# Altium schematic sheet MCU_Power.SchDoc
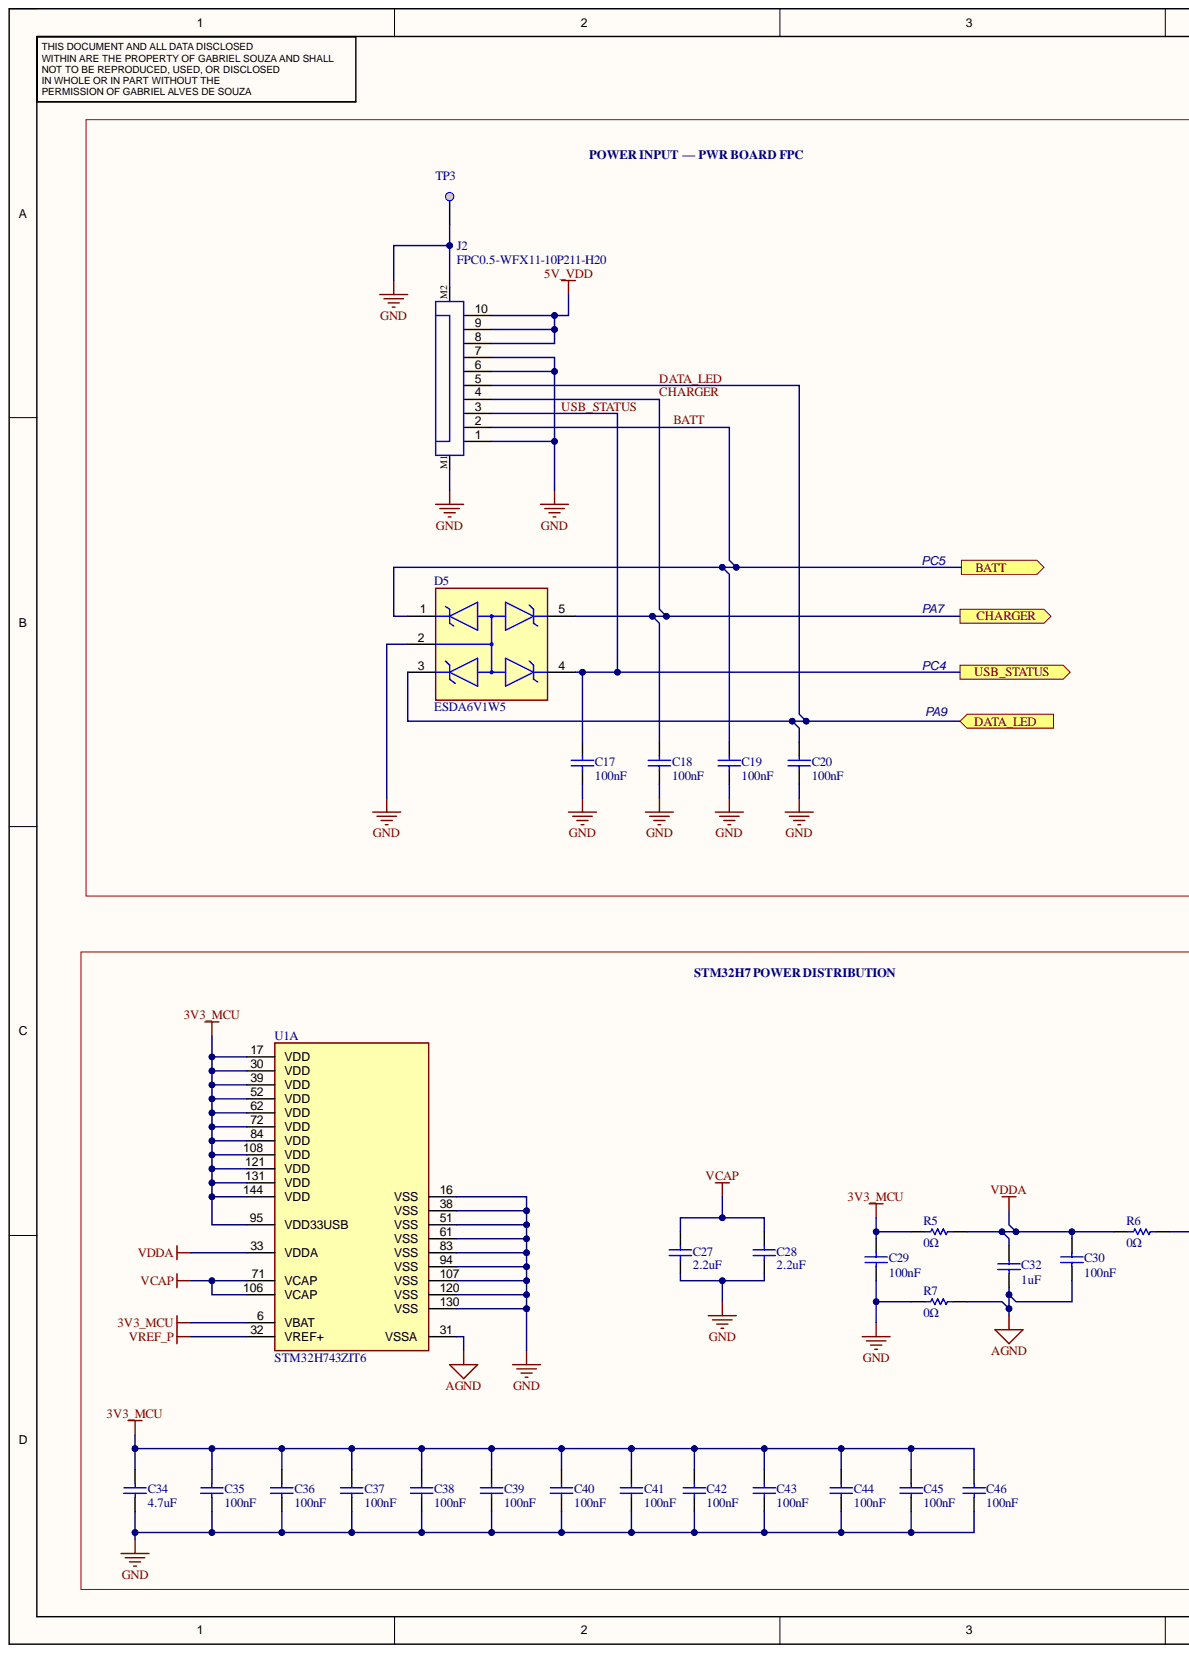
[diagram p0: MCU_Power.SchDoc, left]
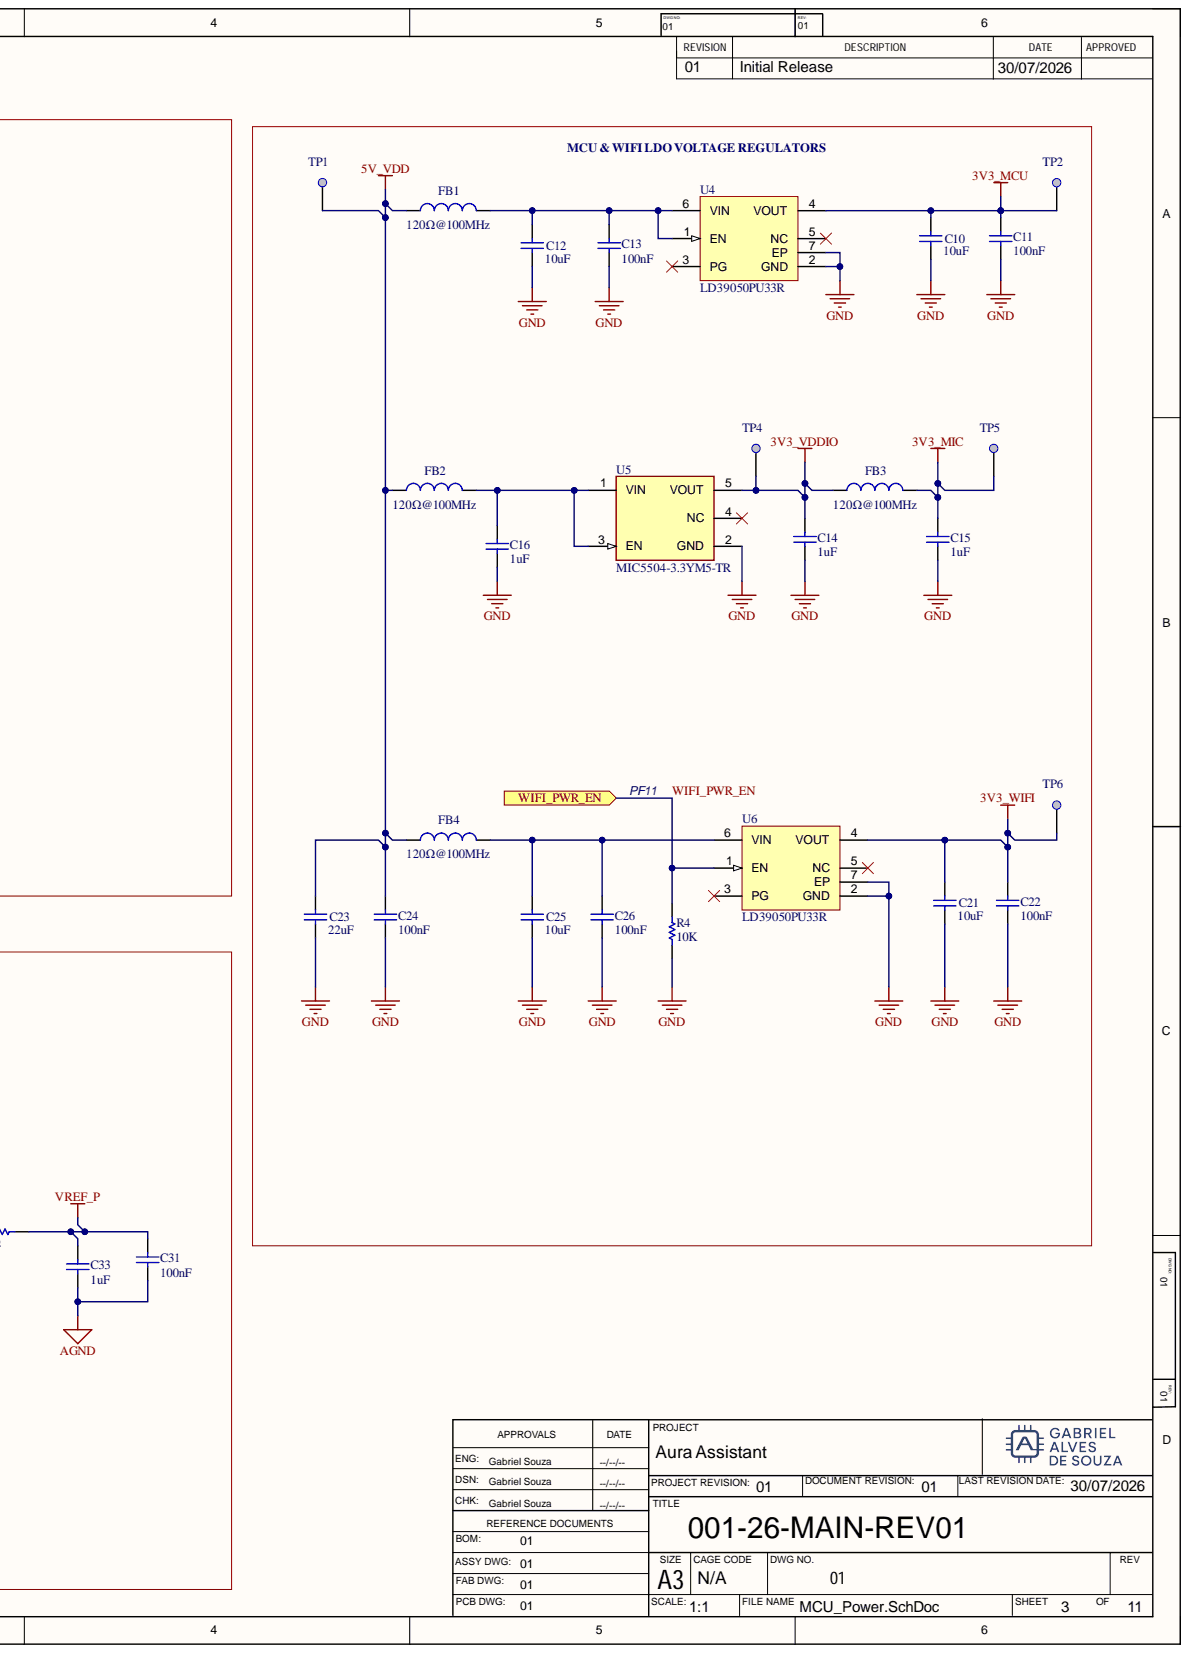
[diagram p1: MCU_Power.SchDoc, right]

## Parts
C10  value 10uF  [C_0805_IPC_N]  (hidden comment: Capacitor)
C11  value 100nF  [C_0402_IPC_N]  (hidden comment: Capacitor)
C12  value 10uF  [C_0805_IPC_N]  (hidden comment: Capacitor)
C13  value 100nF  [C_0402_IPC_N]  (hidden comment: Capacitor)
C14  value 1uF  [C_0402_IPC_N]  (hidden comment: Capacitor)
C15  value 1uF  [C_0402_IPC_N]  (hidden comment: Capacitor)
C16  value 1uF  [C_0402_IPC_N]  (hidden comment: Capacitor)
C17  value 100nF  [C_0402_IPC_N]  (hidden comment: Capacitor)
C18  value 100nF  [C_0402_IPC_N]  (hidden comment: Capacitor)
C19  value 100nF  [C_0402_IPC_N]  (hidden comment: Capacitor)
C20  value 100nF  [C_0402_IPC_N]  (hidden comment: Capacitor)
C21  value 10uF  [C_0805_IPC_N]  (hidden comment: Capacitor)
C22  value 100nF  [C_0402_IPC_N]  (hidden comment: Capacitor)
C23  value 22uF  [C_1206_IPC_N]  (hidden comment: Capacitor)
C24  value 100nF  [C_0402_IPC_N]  (hidden comment: Capacitor)
C25  value 10uF  [C_0805_IPC_N]  (hidden comment: Capacitor)
C26  value 100nF  [C_0402_IPC_N]  (hidden comment: Capacitor)
C27  value 2.2uF  [C_0402_IPC_N]  (hidden comment: Capacitor)
C28  value 2.2uF  [C_0402_IPC_N]  (hidden comment: Capacitor)
C29  value 100nF  [C_0402_IPC_N]  (hidden comment: Capacitor)
C30  value 100nF  [C_0402_IPC_N]  (hidden comment: Capacitor)
C31  value 100nF  [C_0402_IPC_N]  (hidden comment: Capacitor)
C32  value 1uF  [C_0402_IPC_N]  (hidden comment: Capacitor)
C33  value 1uF  [C_0402_IPC_N]  (hidden comment: Capacitor)
C34  value 4.7uF  [C_0805_IPC_N]  (hidden comment: Capacitor)
C35  value 100nF  [C_0402_IPC_N]  (hidden comment: Capacitor)
C36  value 100nF  [C_0402_IPC_N]  (hidden comment: Capacitor)
C37  value 100nF  [C_0402_IPC_N]  (hidden comment: Capacitor)
C38  value 100nF  [C_0402_IPC_N]  (hidden comment: Capacitor)
C39  value 100nF  [C_0402_IPC_N]  (hidden comment: Capacitor)
C40  value 100nF  [C_0402_IPC_N]  (hidden comment: Capacitor)
C41  value 100nF  [C_0402_IPC_N]  (hidden comment: Capacitor)
C42  value 100nF  [C_0402_IPC_N]  (hidden comment: Capacitor)
C43  value 100nF  [C_0402_IPC_N]  (hidden comment: Capacitor)
C44  value 100nF  [C_0402_IPC_N]  (hidden comment: Capacitor)
C45  value 100nF  [C_0402_IPC_N]  (hidden comment: Capacitor)
C46  value 100nF  [C_0402_IPC_N]  (hidden comment: Capacitor)
D5  ESDA6V1W5  [FP-SOT323-5L-MFG]
FB1  value 120Ω@100MHz  [L_0603_IPC_N]  (hidden comment: Inductor)
FB2  value 120Ω@100MHz  [L_0603_IPC_N]  (hidden comment: Inductor)
FB3  value 120Ω@100MHz  [L_0603_IPC_N]  (hidden comment: Inductor)
FB4  value 120Ω@100MHz  [L_0603_IPC_N]  (hidden comment: Inductor)
J2  FPC0.5-WFX11-10P211-H20  [687110149022]
R4  value 10K  [R_0603_IPC_N]  (hidden comment: Resistor)
R5  value 0Ω  [R_0603_IPC_N]  (hidden comment: Resistor)
R6  value 0Ω  [R_0603_IPC_N]  (hidden comment: Resistor)
R7  value 0Ω  [R_0603_IPC_N]  (hidden comment: Resistor)
TP1  Test Point  [TP_SMD_2MM]  (value not drawn)  (no BOM)
TP2  Test Point  [TP_SMD_2MM]  (value not drawn)  (no BOM)
TP3  Test Point  [TP_SMD_2MM]  (value not drawn)  (no BOM)
TP4  Test Point  [TP_SMD_2MM]  (value not drawn)  (no BOM)
TP5  Test Point  [TP_SMD_2MM]  (value not drawn)  (no BOM)
TP6  Test Point  [TP_SMD_2MM]  (value not drawn)  (no BOM)
U1  STM32H743ZIT6  [FP-LQFP144-1A-MFG]  (part A)
U4  LD39050PU33R  [DFN6D_L]
U5  MIC5504-3.3YM5-TR  [FP-SOT23-5LD-PL-1-IPC_B]
U6  LD39050PU33R  [DFN6D_L]

## Ports
BATT, CHARGER, DATA_LED, USB_STATUS, WIFI_PWR_EN

## Nets
3V3_MCU: C10.2 C11.2 C29.2 C34.2 C35.2 C36.2 C37.2 C38.2 C39.2 C40.2 C41.2 C42.2 C43.2 C44.2 C45.2 C46.2 R5.1 TP2.1 U1.6(VBAT) U1.17(VDD) U1.30(VDD) U1.39(VDD) U1.52(VDD) U1.62(VDD) U1.72(VDD) U1.84(VDD) U1.95(VDD33USB) U1.108(VDD) U1.121(VDD) U1.131(VDD) U1.144(VDD) U4.4(VOUT)
3V3_MIC: C15.2 FB3.2 TP5.1
3V3_VDDIO: C14.2 FB3.1 TP4.1 U5.5(VOUT)
3V3_WIFI: C21.2 C22.2 TP6.1 U6.4(VOUT)
5V_VDD: C23.2 C24.2 FB1.1 FB2.1 FB4.1 J2.8 J2.9 J2.10 TP1.1
AGND: C30.1 C31.1 C32.1 C33.1 R7.2 U1.31(VSSA)
GND: C10.1 C11.1 C12.1 C13.1 C14.1 C15.1 C16.1 C17.1 C18.1 C19.1 C20.1 C21.1 C22.1 C23.1 C24.1 C25.1 C26.1 C27.1 C28.1 C29.1 C34.1 C35.1 C36.1 C37.1 C38.1 C39.1 C40.1 C41.1 C42.1 C43.1 C44.1 C45.1 C46.1 D5.2 J2.1 J2.6 J2.7 J2.M1 J2.M2 R4.1 R7.1 TP3.1 U1.16(VSS) U1.38(VSS) U1.51(VSS) U1.61(VSS) U1.83(VSS) U1.94(VSS) U1.107(VSS) U1.120(VSS) U1.130(VSS) U4.2(GND) U4.7(EP) U5.2(GND) U6.2(GND) U6.7(EP)
VCAP: C27.2 C28.2 U1.71(VCAP) U1.106(VCAP)
VDDA: C30.2 C32.2 R5.2 R6.1 U1.33(VDDA)
VREF_P: C31.2 C33.2 R6.2 U1.32(VREF+)
BATT: C19.2 D5.1 J2.2 ; port BATT
CHARGER: C18.2 D5.5 J2.4 ; port CHARGER
DATA_LED: C20.2 D5.3 J2.5 ; port DATA_LED
USB_STATUS: C17.2 D5.4 J2.3 ; port USB_STATUS
WIFI_PWR_EN: R4.2 U6.1(EN) ; port WIFI_PWR_EN
NetC12_2: C12.2 C13.2 FB1.2 U4.1(EN) U4.6(VIN)
NetC16_2: C16.2 FB2.2 U5.1(VIN) U5.3(EN)
NetC25_2: C25.2 C26.2 FB4.2 U6.6(VIN)

Not connected: U4 3(PG) 5(NC); U5 4(NC); U6 3(PG) 5(NC)

## Library data of the parts
C10 C12 C21 C25 = CAP: Capacitor, MLCC, 10uF, 16V, X5R, +/-10%, 0805, T=0,5mm; Manufacturer: muRata; Manufacturer_Part_Number: GRM21BR61C106KE15K; Component Kind: Standard; ComponentLink1Description: Datasheet; ComponentLink1URL: https://www.lcsc.com/datasheet/C338032.pdf?spm=wm.sxq.inf.ggs___wm.fly.bg.6.xh&lcsc_vid=TlIKVlcFRVJWVl1WQVFfBlQDRFgPAVVQRFhaBQIFFgQxVlNeRVRbU1RQQ1dWUTtW; Order_Code_LCSC: C408141; Package: 0805; Library Name: Gabriel_Lib.IntLib
C11 C13 C17-C20 C22 C24 C26 C29-C31 C35-C46 = CAP: Capacitor, MLCC, 100nF, 16V, X7R, +/-10%, 0402, T=0,5mm; Manufacturer: TDK; Manufacturer_Part_Number: C1005X7R1C104KT000F; Component Kind: Standard; ComponentLink1Description: Datasheet; ComponentLink1URL: https://www.lcsc.com/datasheet/C338032.pdf?spm=wm.sxq.inf.ggs___wm.fly.bg.6.xh&lcsc_vid=TlIKVlcFRVJWVl1WQVFfBlQDRFgPAVVQRFhaBQIFFgQxVlNeRVRbU1RQQ1dWUTtW; Order_Code_LCSC: C338032; Package: 0402; Library Name: Gabriel_Lib.IntLib
C14-C16 C32 C33 = CAP: Capacitor, MLCC, 1uF, 10V, X5R, +/-10%, 0402, T=0,5mm; Manufacturer: FOJAN; Manufacturer_Part_Number: FCC0402X105K100AT; Component Kind: Standard; ComponentLink1Description: Datasheet; ComponentLink1URL: https://www.lcsc.com/datasheet/C5137473.pdf?spm=wm.sxq.inf.ggs___wm.fly.bg.0.xh&lcsc_vid=TlIKVlcFRVJWVl1WQVFfBlQDRFgPAVVQRFhaBQIFFgQxVlNeRVRbU1RQQ1dWUTtW; Order_Code_LCSC: C5137473; Package: 0402; Library Name: Gabriel_Lib.IntLib
C23 = CAP: Capacitor, MLCC, 22uF, 16V, X5R, +/-10%, 1206, T=0,5mm; Manufacturer: muRata; Manufacturer_Part_Number: GRM31CR61C226KE15L; Component Kind: Standard; ComponentLink1Description: Datasheet; ComponentLink1URL: https://www.lcsc.com/datasheet/C338032.pdf?spm=wm.sxq.inf.ggs___wm.fly.bg.6.xh&lcsc_vid=TlIKVlcFRVJWVl1WQVFfBlQDRFgPAVVQRFhaBQIFFgQxVlNeRVRbU1RQQ1dWUTtW; Order_Code_LCSC: C77088; Package: 1206; Library Name: Gabriel_Lib.IntLib
C27 C28 = CAP: Capacitor, MLCC, 2.2uF, 10V, X5R, +/-10%, 0402, T=0,5mm; Manufacturer: YAGEO; Manufacturer_Part_Number: CC0402KRX5R6BB225; Component Kind: Standard; ComponentLink1Description: Datasheet; ComponentLink1URL: https://www.lcsc.com/datasheet/C326606.pdf?spm=wm.sxq.inf.ggs___wm.fly.bg.0.xh&lcsc_vid=TlIKVlcFRVJWVl1WQVFfBlQDRFgPAVVQRFhaBQIFFgQxVlNeRVRbU1RQQ1dWUTtW; Order_Code_LCSC: C326606; Package: 0402; Library Name: Gabriel_Lib.IntLib
C34 = CAP: Capacitor, MLCC, 4.7uF, 10V, X5R, +/-10%, 0805, T=0,5mm; Manufacturer: YAGEO; Manufacturer_Part_Number: CC0805KKX5R6BB475; Component Kind: Standard; ComponentLink1Description: Datasheet; ComponentLink1URL: https://www.lcsc.com/datasheet/C107125.pdf?spm=wm.sxq.inf.ggs___wm.fly.bg.0.xh&lcsc_vid=TlIKVlcFRVJWVl1WQVFfBlQDRFgPAVVQRFhaBQIFFgQxVlNeRVRbU1RQQ1dWUTtW; Order_Code_LCSC: C107125; Package: 0805; Library Name: Gabriel_Lib.IntLib
D5 = CMP-12225-000010-1: TVS DIODE 3V SOT323-5; Manufacturer: STMicroelectronics; Breakdown Voltage: 6.1V; Capacitance: 90pF; Direction: Unidirectional; Max Junction Temp: +125°C; Number Of Channels: 2; Peak Pulse Power: 150W; Standoff Height: 0mm; Value: 90pF; Automotive: No; Category: Diode; Component Kind: Standard; ComponentLink2Description: Datasheet; ComponentLink2URL: https://www.st.com/resource/en/datasheet/esdaxxxwx.pdf; Device Class L1: Circuit Protection; Device Class L2: TVS Diodes; Device Class L3: unset; Digikey Description: TVS DIODE 3V SOT323-5; Height: 1.1mm; Ipc Land Pattern Name: SOT65P210X95-5; Lead Free: Yes; Library Name: Altium Content Vault; Manufacturer Part Number: ESDA6V1W5; Mouser Description: TVS Diodes / ESD Suppressors 6.1V 150W Unidirect; Octopart: https://octopart.com/esda6v1w5-stmicroelectronics-39557953; Order_Code_LCSC: C48677; Package: SOT323-5L; Reverse Standoff Voltage: 3V; RoHS: TRUE; Temperature: -40°C to +125°C; Temperature Range High: +125°C; Temperature Range Low: -40°C
FB1-FB4 = Inductor: FERRITE BEAD 120Ω 0603 1LN; Manufacturer: muRata; Manufacturer_Part_Number: BLM18PG121SN1D; Component Kind: Standard; ComponentLink1URL: http://tunmcdsvn.tun.st.com/Component_Documentation_Library/Trunk/Inductor/MURATA_DFE201612P_Series/; Order_Code_LCSC: C14709; Part_Type: Inductor; Library Name: Gabriel_Lib.IntLib
J2 = CMP-1502-01625-3: CONN FFC FPC 10POS 0.5mm BOTTOM CNT SMD R/A; Manufacturer: HMTCONN; Number of Contacts: 10; Component Kind: Standard; Library Name: Altium Content Vault; Manufacturer_Part_Number: FPC0.5-WFX11-10P211-H20; Order_Code_LCSC: C42407926
R4 = RES: Resistor, 10K, 0603, 0.1%, 0.1W; Manufacturer: UNI-ROYAL; Manufacturer_Part_Number: TC0350B1002T5F; Component Kind: Standard; ComponentLink1Description: Datasheet; Order_Code_LCSC: C2989264; Package: 0603; Part_Type: Resistor; Library Name: Gabriel_Lib.IntLib
R5-R7 = RES: Resistor, 0R, 0603, 5%, 0.1W; Manufacturer: FOJAN; Manufacturer_Part_Number: FRC0603P000 TS; Component Kind: Standard; ComponentLink1Description: Datasheet; Order_Code_LCSC: C2907080; Package: 0603; Part_Type: Resistor; Library Name: Gabriel_Lib.IntLib
TP1-TP6 = MCD-00177: Test Point, SMD, 2mm; Part_Preference: Recommended; Part_Type: Misc; Component_Country_Of_Origin: Unknown; Database Table Name: MCD_PARTS$; ID: 2690; ShowFields: YES; ComponentLink1URL: http://tunmcdsvn.tun.st.com/Component_Documentation_Library/Trunk/Misc; Internal_Part_Number: MCD-00177; Library Name: MCD_PARTS_database.DbLib; Manufacturer: N/A; Manufacturer_Part_Number: N/A; Order_Code_Digikey: N/A; Order_Code_Farnell: N/A; Order_Code_RS: N/A; Status: Released; Value: N/A
U1 = CMP-12105-000003-1: IC MCU 32BIT 2MB FLASH 144LQFP; Manufacturer: STMicroelectronics; Core Architecture: ARM Cortex-M7; Data Bus Width: 32bits; Frequency: 400MHz; Interface: I2C,SPI,UART,USART,EBI/EMI,CAN,USB,LIN,IrDA,Other; Max Junction Temp: +125°C; Max Supply Voltage: 3.6V; Memory Size: 2MBytes; Min Supply Voltage: 1.62V; Number Of A/D Converters: 2; Number Of Adc Channels: 36; Number Of Cores: 1; Number Of I2C Channels: 4; Number Of I/Os: 114; Number Of Spi Channels: 6; Number Of Timers/Counters: 22; Ram Size: 1MBytes; Standoff Height: 0.05mm; Ambient Temperature Range High: +85°C; Ambient Temperature Range Low: -40°C; Automotive: No; Category: IC; Component Kind: Standard; ComponentLink2Description: Datasheet; ComponentLink2URL: https://www.st.com/resource/en/datasheet/stm32h743zi.pdf; Device Class L1: Integrated Circuits (ICs); Device Class L2: Embedded Processors and Controllers; Device Class L3: Microcontrollers; Digikey Description: IC MCU 32BIT 2MB FLASH 144LQFP; Height: 1.6mm; Ipc Land Pattern Name: QFP50P2200X2200X160-144; Lead Free: Yes; Library Name: Altium Content Vault; Manufacturer Part Number: STM32H743ZIT6; Memory Type: FLASH; Mouser Description: MCU 32-Bit STM32 ARM Cortex M7 RISC 2MB Flash 1.8V/2.5V/3.3V 144-Pin LQFP Tray; Number Of Pwm Channels: 2; Number Of Uart Channels: 4; Number Of Usb Channels: 2; Octopart: https://octopart.com/stm32h743zit6-stmicroelectronics-76372529; Package: LQFP144-1A; RoHS: TRUE; Temperature: -40°C to +85°C; Temperature Range High: +85°C; Temperature Range Low: -40°C
U4 U6 = CMP-0244-00288-1: 500 mA Low Quiescent Current Low Noise Voltage Regulator, 3.3V, 6-Pin VDFDPN, Tape and Reel; Component Kind: Standard; ComponentLink1Description: Manufacturer URL; ComponentLink1URL: http://www.st.com; ComponentLink2Description: Datasheet; ComponentLink2URL: http://www.st.com/internet/com/TECHNICAL_RESOURCES/TECHNICAL_LITERATURE/DATASHEET/CD00227527.pdf; DatasheetVersion: 1/03/2009; Library Name: Altium Content Vault; Order_Code_LCSC: C132827; PackageDescription: 6-Pin Plastic, Dual LLP, 3 x 3 mm Body, 0.95 mm Pitch, Exposed Pad; PackageReference: DFN6D; PackageVersion: 2008
U5 = CMP-2000-07604-2: IC REG LINEAR 3.3V 300MA SOT23-5; Accuracy: 3%; Dropout Voltage: 380mV; Max Input Voltage: 5.5V; Min Input Voltage: 2.5V; Number of Outputs: 1; Operating Supply Current: 42uA; Output Type: Fixed; Power Supply Rejection Ratio (PSRR): 60dB; Quiescent Current: 38uA; Case/Package: SOT-23-5; Component Kind: Standard; Height: 1.1mm; Length: 2.9mm; Library Name: Altium Content Vault; Max Operating Temperature: 125°C; Max Output Current: 300mA; Min Operating Temperature: -40°C; Mount: Surface Mount; Nominal Output Voltage: 3.3V; Number of Pins: 5; Order_Code_LCSC: C88419; Output Current: 300mA; Output Voltage: 3.3V; Packaging: Tape and Reel; REACH SVHC: No SVHC; Width: 1.6mm
